# Altium schematic sheet Ethernet.SchDoc(Ethernet)
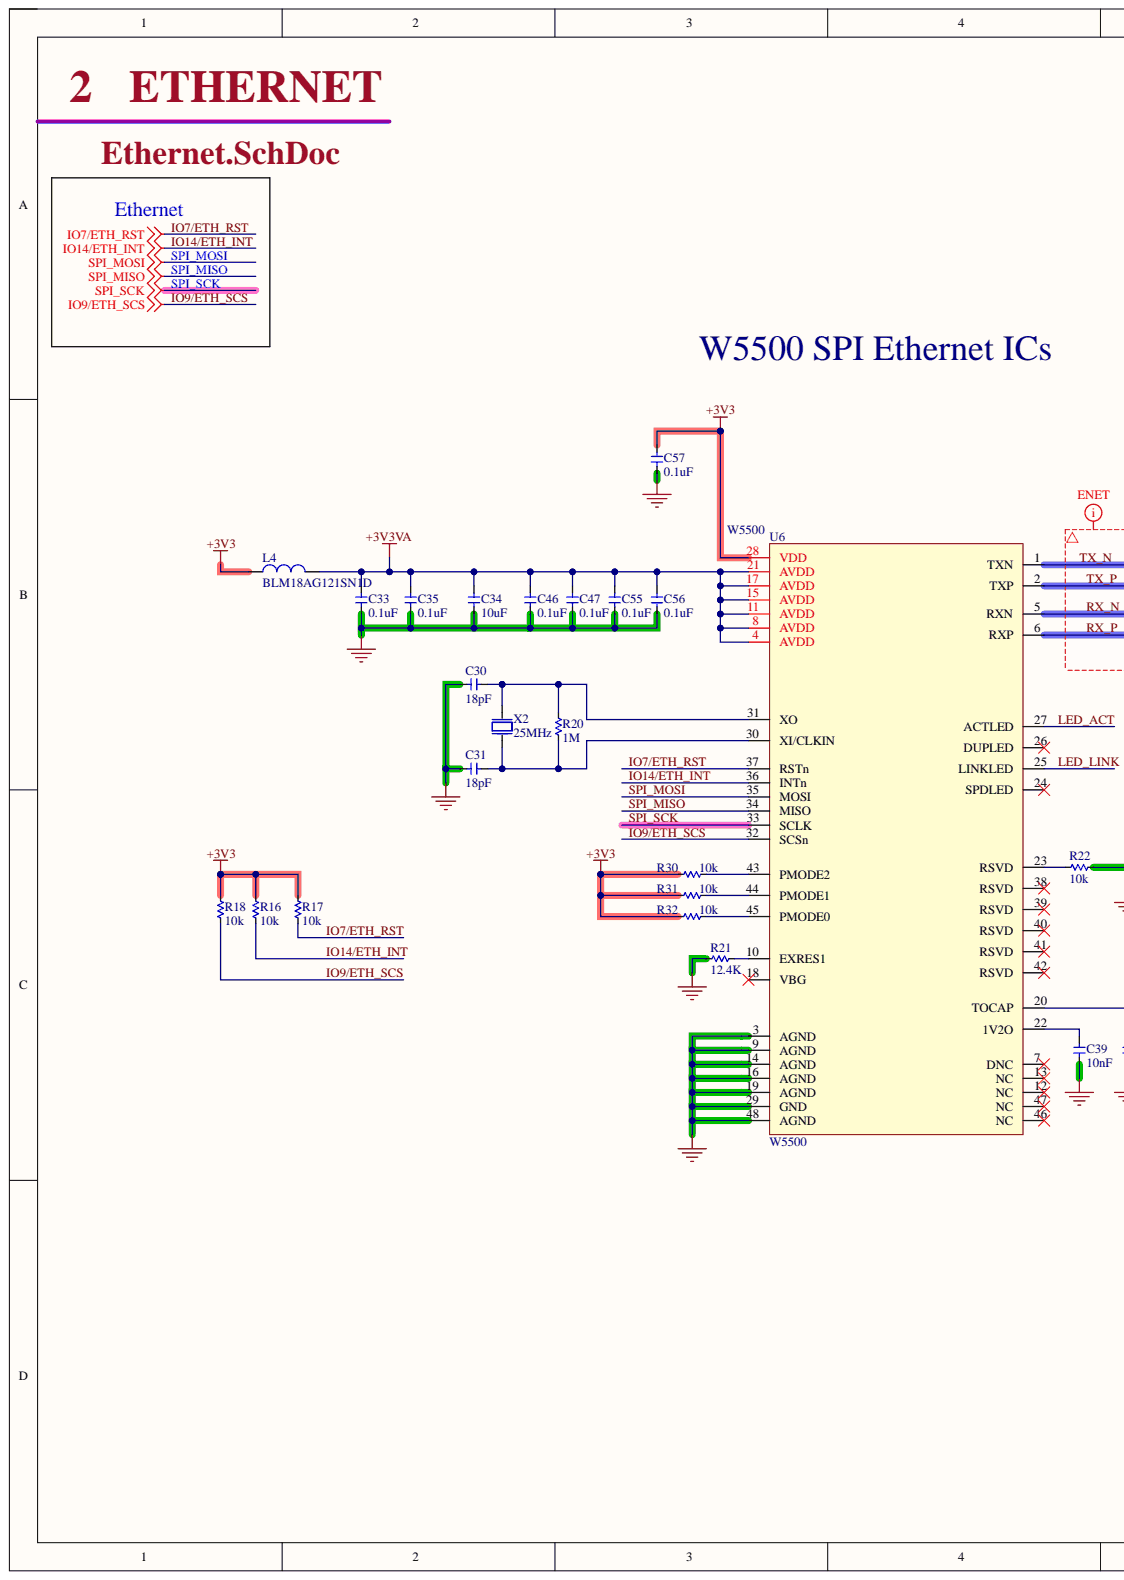
[diagram p0: Ethernet.SchDoc, left]
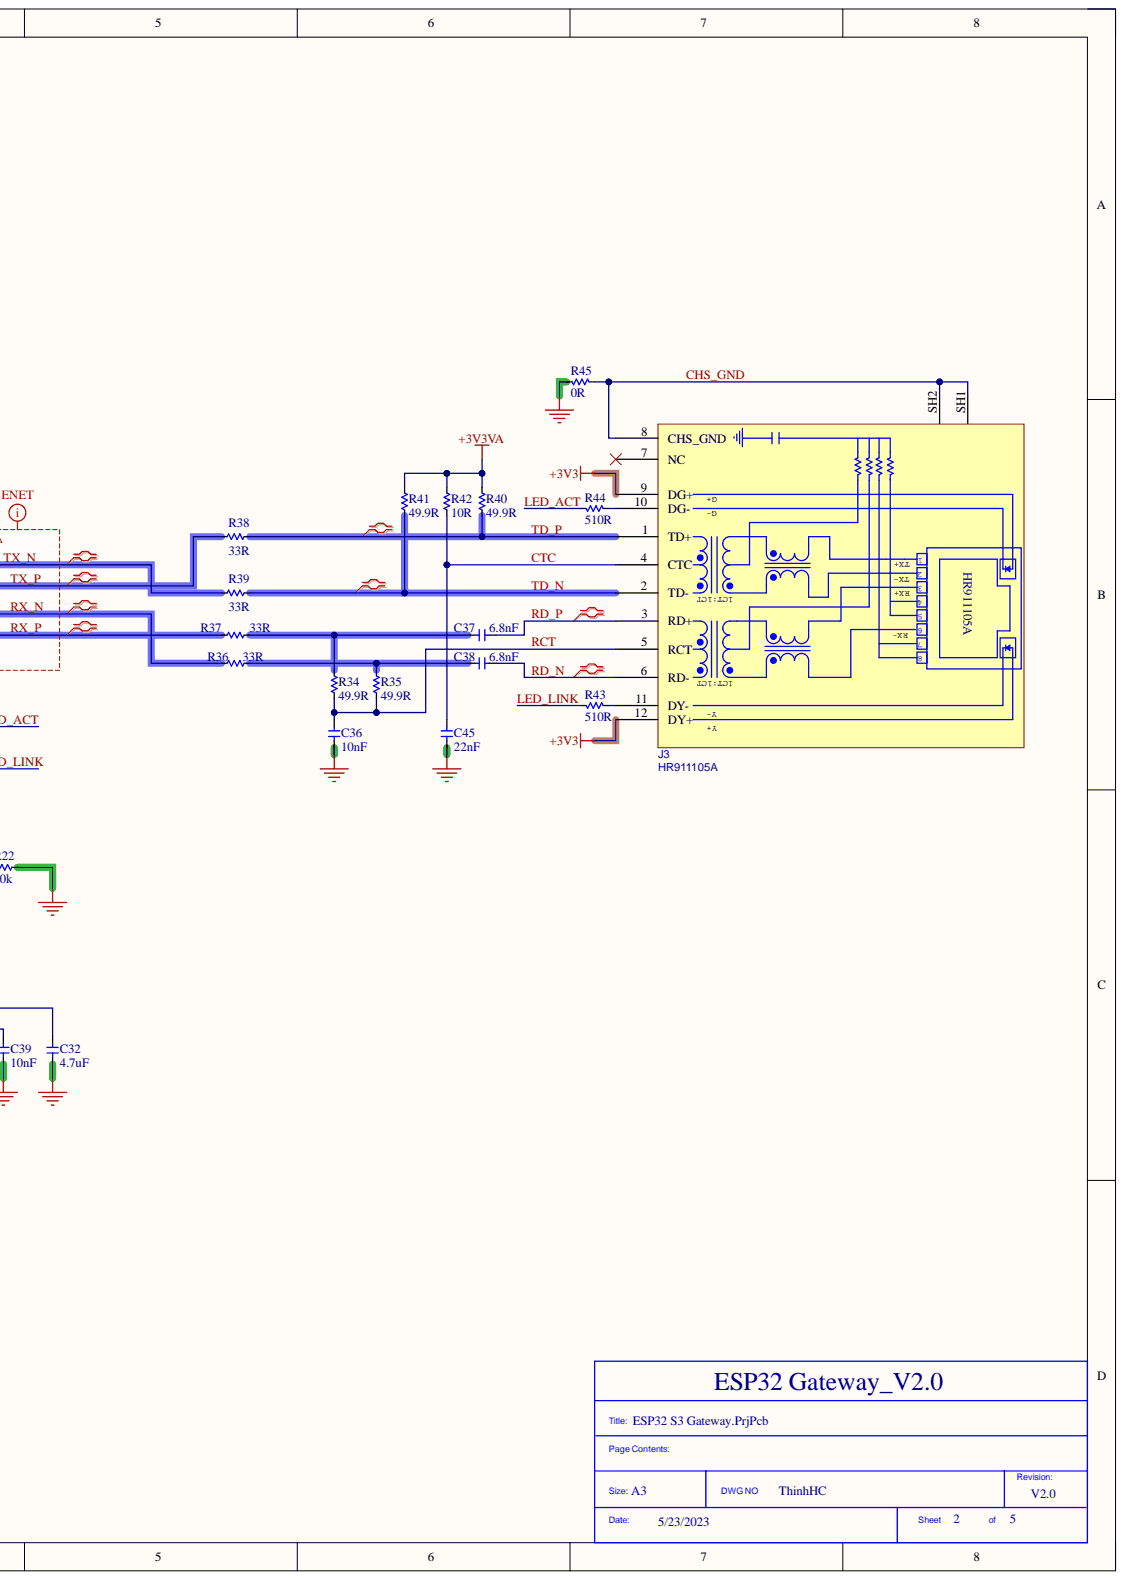
[diagram p1: Ethernet.SchDoc, right]

## Parts
C30  18pF  [C_0402]
C31  18pF  [C_0402]
C32  4.7uF  [C_0402]
C33  0.1uF  [C_0402]
C34  10uF  [C_0402]
C35  0.1uF  [C_0402]
C36  10nF  [C_0402]
C37  6.8nF  [C_0402]
C38  6.8nF  [C_0402]
C39  10nF  [C_0402]
C45  22nF  [C_0402]
C46  0.1uF  [C_0402]
C47  0.1uF  [C_0402]
C55  0.1uF  [C_0402]
C56  0.1uF  [C_0402]
C57  0.1uF  [C_0402]
J3  HR911105A  [RJ45-TH_HR911105A]
L4  BLM18AG121SN1D  [L_0603]
R16  10k  [R_0402]
R17  10k  [R_0402]
R18  10k  [R_0402]
R20  1M  [R_0402]
R21  12.4K  [R_0402]
R22  10k  [R_0402]
R30  10k  [R_0402]
R31  10k  [R_0402]
R32  10k  [R_0402]
R34  49.9R  [R_0402]
R35  49.9R  [R_0402]
R36  33R  [R_0402]
R37  33R  [R_0402]
R38  33R  [R_0402]
R39  33R  [R_0402]
R40  49.9R  [R_0402]
R41  49.9R  [R_0402]
R42  10R  [R_0402]
R43  510R  [R_0402]
R44  510R  [R_0402]
R45  0R  [R_0603]
U6  W5500  [LQFP-48_L7.0-W7.0-P0.50-LS9.0-BL]
X2  25MHz  [OSC-SMD_L5.0-W3.2]

## Nets
+3V3: C57.2 J3.9(DG+) J3.12(DY+) L4.1 R16.2 R17.2 R18.2 R30.1 R31.1 R32.1 U6.28(VDD)
+3V3VA: C33.2 C34.2 C35.2 C46.2 C47.2 C55.2 C56.2 L4.2 R40.2 R41.2 R42.2 U6.4(AVDD) U6.8(AVDD) U6.11(AVDD) U6.15(AVDD) U6.17(AVDD) U6.21(AVDD)
GND: C30.1 C31.1 C32.2 C33.1 C34.1 C35.1 C36.2 C39.1 C45.1 C46.1 C47.1 C55.1 C56.1 C57.1 R21.1 R22.2 R45.1 U6.3(AGND) U6.9(AGND) U6.14(AGND) U6.16(AGND) U6.19(AGND) U6.29(GND) U6.48(AGND)
CHS_GND: J3.8(CHS_GND) J3.SH1 J3.SH2 R45.2
CTC: C45.2 J3.4(CTC) R42.1
IO7/ETH_RST: R17.1 U6.37(RSTn)
IO9/ETH_SCS: R18.1 U6.32(SCSn)
IO14/ETH_INT: R16.1 U6.36(INTn)
LED_ACT: R44.1 U6.27(ACTLED)
LED_LINK: R43.1 U6.25(LINKLED)
RCT: C36.1 J3.5(RCT) R34.2 R35.1
RD_N: C38.2 J3.6(RD-)
RD_P: C37.2 J3.3(RD+)
RX_N: R36.1 U6.5(RXN)
RX_P: R37.1 U6.6(RXP)
SPI_MISO: U6.34(MISO)
SPI_MOSI: U6.35(MOSI)
SPI_SCK: U6.33(SCLK)
TD_N: J3.2(TD-) R39.2 R41.1
TD_P: J3.1(TD+) R38.2 R40.1
TX_N: R39.1 U6.1(TXN)
TX_P: R38.1 U6.2(TXP)
NetC30_2: C30.2 R20.2 U6.31(XO) X2.2
NetC31_2: C31.2 R20.1 U6.30(XI/CLKIN) X2.1
NetC32_1: C32.1 U6.20(TOCAP)
NetC37_1: C37.1 R34.1 R37.2
NetC38_1: C38.1 R35.2 R36.2
NetC39_2: C39.2 U6.22(1V2O)
NetJ3_10: J3.10(DG-) R44.2
NetJ3_11: J3.11(DY-) R43.2
NetR21_2: R21.2 U6.10(EXRES1)
NetR22_1: R22.1 U6.23(RSVD)
NetR30_2: R30.2 U6.43(PMODE2)
NetR31_2: R31.2 U6.44(PMODE1)
NetR32_2: R32.2 U6.45(PMODE0)

Not connected: J3 7(NC); U6 7(DNC) 12(NC) 13(NC) 18(VBG) 24(SPDLED) 26(DUPLED) 38(RSVD) 39(RSVD) 40(RSVD) 41(RSVD) 42(RSVD) 46(NC) 47(NC)

## Library data of the parts
C30 C31 = 0402CG180J500NT: 50V 18pF C0G ±5% 0402 Multilayer Ceramic Capacitors MLCC - SMD/SMT ROHS; Manufacturer: FH (Guangdong Fenghua Advanced Tech); Assembly Type: SMT Assembly; Component Kind: Standard; ComponentLink1Description: Digikey Link; ComponentLink1URL: https://www.digikey.com/en/products/detail/yageo/CC0603KRX7R9BB104/2103082?s=N4IgTCBcDaIMwEYEFoFwCzuWZA5AIiALoC%2BQA; ComponentLink2Description: LCSC Link; ComponentLink2URL: https://jlcpcb.com/parts/componentSearch?searchTxt=10k%200603; LCSC Part Number: C1549; Library Name: ESP32 Gateway_V2.0.SchLib; Manufacturer Part Number: 0402CG180J500NT; Package: 0402
C32 = CL05A475MP5NRNC: 10V 4.7uF X5R ±20% 0402 Multilayer Ceramic Capacitors MLCC - SMD/SMT ROHS; Manufacturer: Samsung Electro-Mechanics; Assembly Type: SMT Assembly; Component Kind: Standard; ComponentLink1Description: Digikey Link; ComponentLink1URL: https://www.digikey.com/en/products/detail/yageo/CC0603KRX7R9BB104/2103082?s=N4IgTCBcDaIMwEYEFoFwCzuWZA5AIiALoC%2BQA; ComponentLink2Description: LCSC Link; ComponentLink2URL: https://jlcpcb.com/parts/componentSearch?searchTxt=10k%200603; LCSC Part Number: C23733; Library Name: ESP32 Gateway_V2.0.SchLib; Manufacturer Part Number: CL05A475MP5NRNC; Package: 0402
C33 C35 C46 C47 C55-C57 = CL05B104KB54PNC: 50V 100nF X7R ±10% 0402 Multilayer Ceramic Capacitors MLCC - SMD/SMT ROHS; Manufacturer: Samsung Electro-Mechanics; Assembly Type: SMT Assembly; Component Kind: Standard; ComponentLink1Description: Digikey Link; ComponentLink1URL: https://www.digikey.com/en/products/detail/yageo/CC0603KRX7R9BB104/2103082?s=N4IgTCBcDaIMwEYEFoFwCzuWZA5AIiALoC%2BQA; ComponentLink2Description: LCSC Link; ComponentLink2URL: https://jlcpcb.com/parts/componentSearch?searchTxt=10k%200603; LCSC Part Number: C307331; Library Name: ESP32 Gateway_V2.0.SchLib; Manufacturer Part Number: CL05B104KB54PNC; Package: 0402
C34 = CL05A106MQ5NUNC: 6.3V 10uF X5R ±20% 0402 Multilayer Ceramic Capacitors MLCC - SMD/SMT ROHS; Manufacturer: Samsung Electro-Mechanics; Assembly Type: SMT Assembly; Component Kind: Standard; ComponentLink1Description: Digikey Link; ComponentLink1URL: https://www.digikey.com/en/products/detail/yageo/CC0603KRX7R9BB104/2103082?s=N4IgTCBcDaIMwEYEFoFwCzuWZA5AIiALoC%2BQA; ComponentLink2Description: LCSC Link; ComponentLink2URL: https://jlcpcb.com/parts/componentSearch?searchTxt=10k%200603; LCSC Part Number: C15525; Library Name: ESP32 Gateway_V2.0.SchLib; Manufacturer Part Number: CL05A106MQ5NUNC; Package: 0402
C36 C39 = CL05B103KB5NNNC: 50V 10nF X7R ±10% 0402 Multilayer Ceramic Capacitors MLCC - SMD/SMT ROHS; Manufacturer: Samsung Electro-Mechanics; Assembly Type: SMT Assembly; Component Kind: Standard; ComponentLink1Description: Digikey Link; ComponentLink1URL: https://www.digikey.com/en/products/detail/yageo/CC0603KRX7R9BB104/2103082?s=N4IgTCBcDaIMwEYEFoFwCzuWZA5AIiALoC%2BQA; ComponentLink2Description: LCSC Link; ComponentLink2URL: https://jlcpcb.com/parts/componentSearch?searchTxt=10k%200603; LCSC Part Number: C15195; Manufacturer Part Number: CL05B103KB5NNNC; Package: 0402
  C36: Library Name: ESP32 Gateway_V2.0.SchLib
  C39: Library Name: ESP32 S3 Gateway.SchLib
C37 C38 = 0402B682K500NT: 50V 6.8nF X7R ±10% 0402 Multilayer Ceramic Capacitors MLCC - SMD/SMT ROHS; Manufacturer: FH (Guangdong Fenghua Advanced Tech); Assembly Type: SMT Assembly; Component Kind: Standard; ComponentLink1Description: Digikey Link; ComponentLink1URL: https://www.digikey.com/en/products/detail/yageo/CC0603KRX7R9BB104/2103082?s=N4IgTCBcDaIMwEYEFoFwCzuWZA5AIiALoC%2BQA; ComponentLink2Description: LCSC Link; ComponentLink2URL: https://jlcpcb.com/parts/componentSearch?searchTxt=10k%200603; LCSC Part Number: C1542; Library Name: ESP32 Gateway_V2.0.SchLib; Manufacturer Part Number: 0402B682K500NT; Package: 0402
C45 = 0402B223K500NT: 50V 22nF X7R ±10% 0402 Multilayer Ceramic Capacitors MLCC - SMD/SMT ROHS; Manufacturer: FH (Guangdong Fenghua Advanced Tech); Assembly Type: SMT Assembly; Component Kind: Standard; ComponentLink1Description: Digikey Link; ComponentLink1URL: https://www.digikey.com/en/products/detail/yageo/CC0603KRX7R9BB104/2103082?s=N4IgTCBcDaIMwEYEFoFwCzuWZA5AIiALoC%2BQA; ComponentLink2Description: LCSC Link; ComponentLink2URL: https://jlcpcb.com/parts/componentSearch?searchTxt=10k%200603; LCSC Part Number: C1532; Library Name: ESP32 S3 Gateway.SchLib; Manufacturer Part Number: 0402B223K500NT; Package: 0402
J3 = HR911105A: RJ45 RJReceptacle 1 WithLED Plugin Ethernet Connectors/Modular Connectors (RJ45 RJ11) ROHS; Manufacturer: HANRUN(Zhongshan HanRun Elec); Assembly Type: Wave Soldering; Component Kind: Standard; LCSC Part Number: C12074; Library Name: ESP32 Gateway_V2.0.SchLib; Manufacturer Part Number: HR911105A; Package: Plugin
L4 = FB_BLM18AG121SN1D: 120Ω @ 100MHz 500mA 1 180mΩ 0603 Ferrite Beads RoHS; Manufacturer: Murata Electronics; Manufacturer Part Number: MWSA0402S-100MT; Assembly Type: SMT Assembly; Component Kind: Standard; LCSC Part Number: C76892; Library Name: ESP32 S3 Gateway.SchLib; Package: 0603
R16-R18 R22 R30-R32 = 0402WGF1002TCE: 10kΩ 1% 0402 Chip Resistor - Surface Mount ROHS; Manufacturer: UNI-ROYAL(Uniroyal Elec); Assembly Type: SMT Assembly; Component Kind: Standard; LCSC Part Number: C25744; Library Name: ESP32 S3 Gateway.SchLib; Manufacturer Part Number: 0402WGF1002TCE; Package: 0402
R20 = 0402WGF1004TCE: 62.5mW Thick Film Resistors ±1% 1MΩ 0402 Chip Resistor - Surface Mount ROHS; Manufacturer: UNI-ROYAL(Uniroyal Elec); Assembly Type: SMT Assembly; Component Kind: Standard; LCSC Part Number: C26083; Library Name: ESP32 Gateway_V2.0.SchLib; Manufacturer Part Number: 0402WGF1004TCE; Package: 0402
R21 = 0402WGF1242TCE: 62.5mW Thick Film Resistors ±1% 12.4kΩ 0402 Chip Resistor - Surface Mount ROHS; Manufacturer: UNI-ROYAL(Uniroyal Elec); Assembly Type: SMT Assembly; Component Kind: Standard; LCSC Part Number: C11692; Library Name: ESP32 S3 Gateway.SchLib; Manufacturer Part Number: 0402WGF1242TCE; Package: 0402
R34 R35 R40 R41 = 0402WGF499JTCE: 62.5mW Thick Film Resistors ±1% 49.9Ω 0402 Chip Resistor - Surface Mount ROHS; Manufacturer: UNI-ROYAL(Uniroyal Elec); Assembly Type: SMT Assembly; Component Kind: Standard; LCSC Part Number: C25120; Library Name: ESP32 Gateway_V2.0.SchLib; Manufacturer Part Number: 0402WGF499JTCE; Package: 0402
R36-R39 = 0603WAF330JT5E: 62.5mW Thick Film Resistors ±1% 33Ω 0402 Chip Resistor - Surface Mount ROHS; Manufacturer: UNI-ROYAL(Uniroyal Elec); Manufacturer Part Number: 0402WGF330JTCE; Assembly Type: SMT Assembly; Component Kind: Standard; LCSC Part Number: C25105; Library Name: ESP32 Gateway_V2.0.SchLib; Package: 0402
R42 = 0402WGF100JTCE: 62.5mW Thick Film Resistors ±1% 10Ω 0402 Chip Resistor - Surface Mount ROHS; Manufacturer: UNI-ROYAL(Uniroyal Elec); Assembly Type: SMT Assembly; Component Kind: Standard; LCSC Part Number: C25077; Library Name: ESP32 Gateway_V2.0.SchLib; Manufacturer Part Number: 0402WGF100JTCE; Package: 0402
R43 R44 = 0402WGF5100TCE: 62.5mW Thick Film Resistors ±1% 510Ω 0402 Chip Resistor - Surface Mount ROHS; Manufacturer: UNI-ROYAL(Uniroyal Elec); Assembly Type: SMT Assembly; Component Kind: Standard; LCSC Part Number: C25123; Library Name: ESP32 Gateway_V2.0.SchLib; Manufacturer Part Number: 0402WGF5100TCE; Package: 0402
R45 = 0603WAF0000T5E: 100mW Thick Film Resistors ±1% 0Ω 0603 Chip Resistor - Surface Mount ROHS; Manufacturer: UNI-ROYAL(Uniroyal Elec); Assembly Type: SMT Assembly; Component Kind: Standard; LCSC Part Number: C21189; Library Name: ESP32 Gateway_V2.0.SchLib; Manufacturer Part Number: 0603WAF0000T5E; Package: 0603
U6 = W5500: W5500 SPI LQFP-48(7x7) Ethernet ICs ROHS; Manufacturer: WIZNET; Assembly Type: SMT Assembly; Component Kind: Standard; LCSC Part Number: C32843; Library Name: ESP32 S3 Gateway.SchLib; Manufacturer Part Number: W5500; Package: LQFP-48(7x7); Supplier 1: Arrow Electronics; Supplier Part Number 1: W5500
X2 = X503225MSB2GI: 25MHz 20pF ±10ppm ±50ppm SMD5032-2P Crystals ROHS; Manufacturer: Yangxing Tech; Assembly Type: SMT Assembly; Component Kind: Standard; LCSC Part Number: C112569; Library Name: ESP32 Gateway_V2.0.SchLib; Manufacturer Part Number: X503225MSB2GI; Package: SMD5032-2P
